# Altium schematic sheet sterio_mic MCU.SchDoc
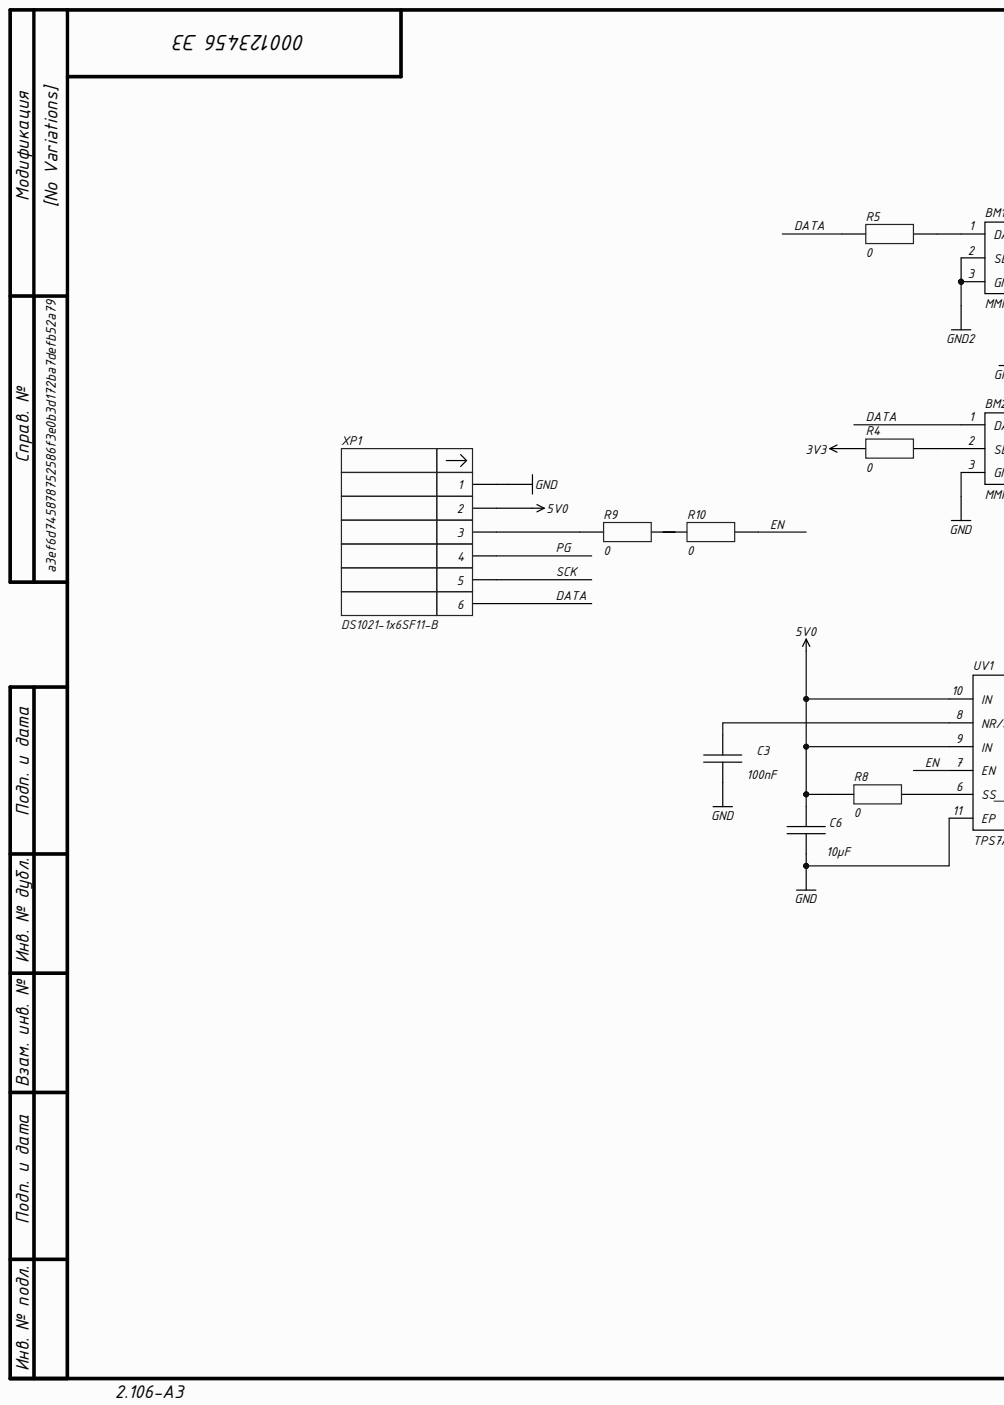
[diagram p0: sterio_mic MCU.SchDoc, left]
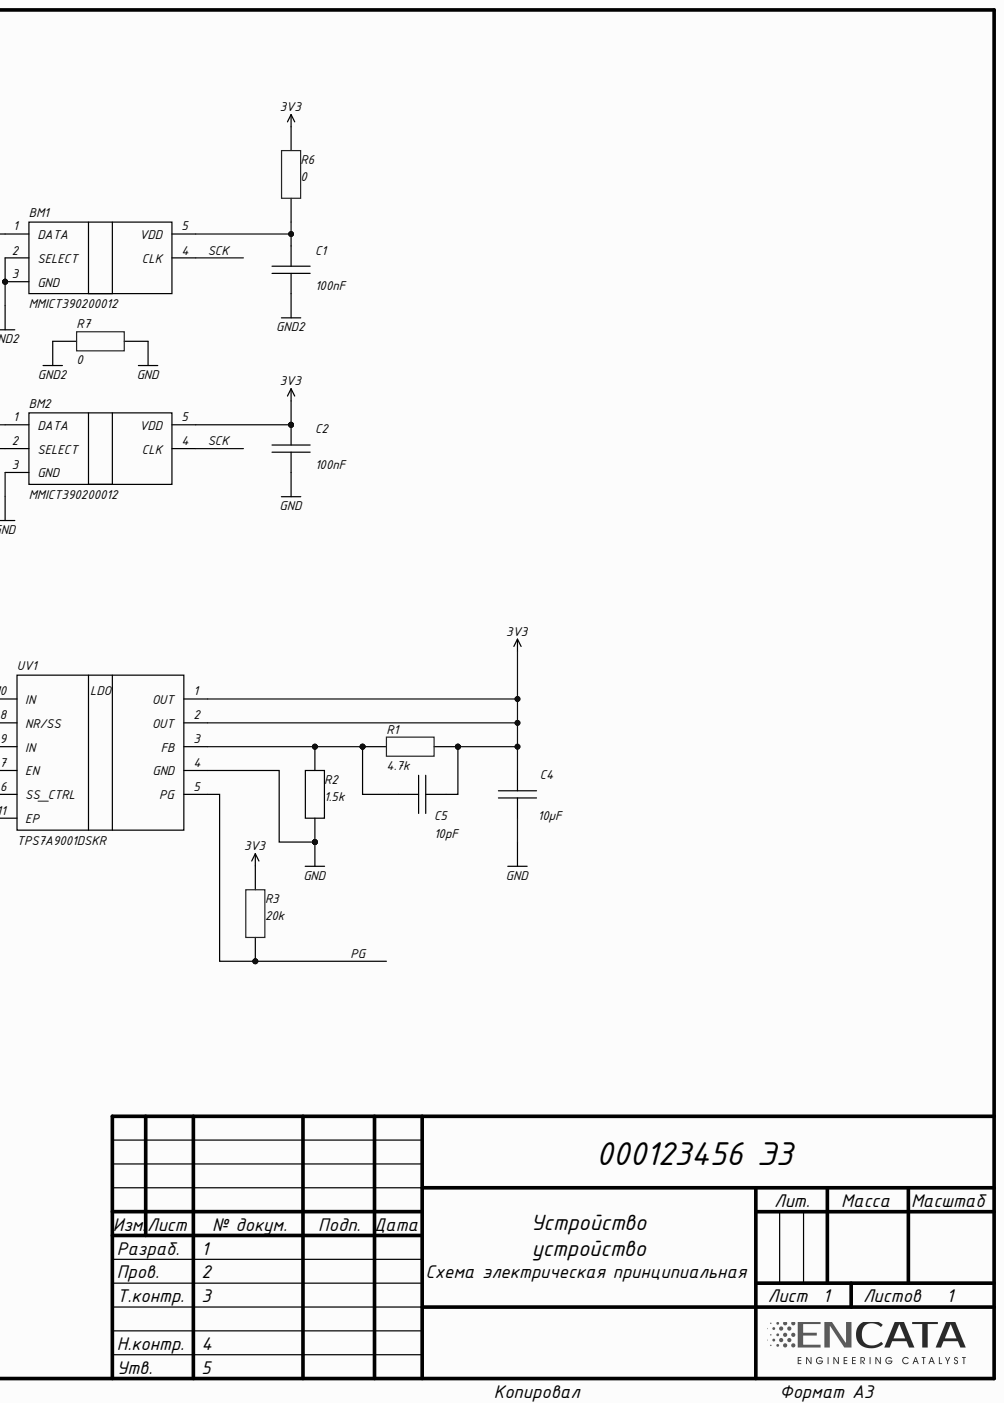
[diagram p1: sterio_mic MCU.SchDoc, right]

## Parts
BM1  MMICT390200012  [5-Terminal LGA_CAV]
BM2  MMICT390200012  [5-Terminal LGA_CAV]
C1  Capacitance: 100nF  [CAPC0805_H0.95_N]  (hidden comment: CC0805KPX7R9BB104)
C2  Capacitance: 100nF  [CAPC0805_H0.95_N]  (hidden comment: CC0805KPX7R9BB104)
C3  Capacitance: 100nF  [CAPC0805_H0.95_N]  (hidden comment: CC0805KPX7R9BB104)
C4  Capacitance: 10µF  [CAPC0805_H1.45_N]  (hidden comment: CL21B106KOQNNNE)
C5  Capacitance: 10pF  [CAPC0805_H0.95_N]  (hidden comment: CC0805FRNPO9BN100)
C6  Capacitance: 10µF  [CAPC0805_H1.45_N]  (hidden comment: CL21B106KOQNNNE)
R1  Resistance: 4.7k  [RESC0805_N]  (hidden comment: RC0805FR-074K7L)
R2  Resistance: 1.5k  [RESC0805_N]  (hidden comment: ERA6AEB152V)
R3  Resistance: 20k  [RESC0805_N]  (hidden comment: RC0805FR-0720KL)
R4  RC0805FR-070RL  [RESC0805_N]  (value not drawn)
R5  RC0805FR-070RL  [RESC0805_N]  (value not drawn)
R6  RC0805FR-070RL  [RESC0805_N]  (value not drawn)
R7  RC0805FR-070RL  [RESC0805_N]  (value not drawn)
R8  RC0805FR-070RL  [RESC0805_N]  (value not drawn)
R9  RC0805FR-070RL  [RESC0805_N]  (value not drawn)
R10  RC0805FR-070RL  [RESC0805_N]  (value not drawn)
UV1  TPS7A9001DSKR  [WSON-10]
XP1  DS1021-1x6SF11-B  [PLS-6(DS-1021-1x6)]

## Nets
3V3: BM2.5(VDD) C2.1 C4.1 C5.1 R1.1 R3.1 R4.1 R6.2 UV1.1(OUT) UV1.2(OUT)
5V0: C6.1 R8.1 UV1.9(IN) UV1.10(IN) XP1.2
GND: BM2.3(GND) C2.2 C3.2 C4.2 C6.2 R2.1 R7.1 UV1.4(GND) UV1.11(EP) XP1.1
GND2: BM1.2(SELECT) BM1.3(GND) C1.2 R7.2
DATA: BM2.1(DATA) R5.1 XP1.6
EN: R10.1 UV1.7(EN)
PG: R3.2 UV1.5(PG) XP1.4
SCK: BM1.4(CLK) BM2.4(CLK) XP1.5
NetBM1_1: BM1.1(DATA) R5.2
NetBM1_5: BM1.5(VDD) C1.1 R6.1
NetBM2_2: BM2.2(SELECT) R4.2
NetC3_1: C3.1 UV1.8(NR/SS)
NetC5_2: C5.2 R1.2 R2.2 UV1.3(FB)
NetR8_2: R8.2 UV1.6(SS_CTRL)
NetR9_1: R9.1 XP1.3
NetR9_2: R9.2 R10.2

## Library data of the parts
BM1 BM2 = CMP-SENS-0013-8: digital MEMS microphone; Manufacturer: TDK; Interface Type: PDM; Case/Package: LGA; Component Kind: Standard; HelpURL: https://static.chipdip.ru/lib/672/DOC011672161.pdf; Manufacturer Part Name: MMICT390200012; Author: t.dorakh; Library Name: EnCata Concord Pro
C1-C3 = CMP-CAP-CER-SMD-0001-5: 0805 100nF 50V ±10% X7R; Manufacturer: Yageo; Case Code (Imperial): 0805; Case Code (Metric): 2012; Case/Package: 0805; Component Kind: Standard; Depth: 850µm; Dielectric: X7R; Height: 850µm; HelpURL: https://www.yageo.com/upload/media/product/products/datasheet/mlcc/UPY-GPHC_X7R_6.3V-to-50V_20.pdf; Lead Free: Yes; Length: 2mm; Manufacturer Part Number: CC0805KPX7R9BB104; Series: СС; Tolerance: 10%; Voltage Rating: 50V; Width: 1.25mm; Author: m.seliadtsou; Library Name: EnCata Concord Pro
C4 C6 = CMP-CAP-CER-SMD-0082-5: 0805 10uF 16V ±10% X7R; Manufacturer: Samsung Electro-Mechanics; Case Code (Imperial): 0805; Case Code (Metric): 2012; Case/Package: 0805; Component Kind: Standard; Depth: 1.25mm; Dielectric: X7R; Height: 1.25mm; HelpURL: https://weblib.samsungsem.com/mlcc/mlcc-ec-data-sheet.do?partNumber=CL21B106KOQNFN; Lead Free: Yes; Length: 2mm; Manufacturer Part Number: CL21B106KOQNNNE; Max Operating Temperature: 125°C; Min Operating Temperature: -55°C; Series: CL; Tolerance: 10%; Voltage Rating: 16V; Width: 1.25mm; Author: m.boltromeyuk; Library Name: EnCata Concord Pro
C5 = CMP-CAP-CER-SMD-1997-4: 0805 10pF 50V ±1% NP0; Manufacturer: Yageo; Dielectric: C0G; Case Code (Imperial): 0805; Case Code (Metric): 2012; Case/Package: 0805; Component Kind: Standard; Depth: 600µm; Height: 600µm; HelpURL: http://www.yageo.ru/pdf/NP0_16V-to-100V.pdf; Lead Free: Yes; Length: 2mm; Manufacturer Part Number: CC0805FRNPO9BN100; Max Operating Temperature: 125°C; Min Operating Temperature: -55°C; Series: CC; Tolerance: 1%; Voltage Rating: 50V; Width: 1.25mm; Author: v.ivanchenko; Library Name: EnCata Concord Pro
R1 = CMP-RES-SMD-0600-6: RES SMD 4,7 KOHM 1% 1/8W 0805; Manufacturer: Yageo; Max Power Dissipation: 125mW; Temperature Coefficient: ±100ppm/C°; Voltage Rating: 150V; Case/Package: 0805; Component Kind: Standard; HelpURL: https://www.yageo.com/upload/media/product/productsearch/datasheet/rchip/PYu-RC_Group_51_RoHS_L_11.pdf; Manufacturer Part Number: RC0805FR-074K7L; Max Operating Temperature: 155°C; Min Operating Temperature: -55°C; Tolerance: 1%; Author: M.S. Koval; Library Name: EnCata Concord Pro
R2 = CMP-RES-SMD-0992-2: RES SMD 1.5 KOHM 0.1% 1/8W 0805  25ppm; Manufacturer: Panasonic Industrial Europe GmbH; Max Power Dissipation: 125mW; Temperature Coefficient: ±25ppm/C°; Voltage Rating: 100V; Case/Package: 0805; Component Kind: Standard; HelpURL: https://mediap.industry.panasonic.eu/assets/imported/industrial.panasonic.com/cdbs/www-data/pdf/RDM0000/AOA0000C307.pdf; Manufacturer Part Number: ERA6AEB152V; Max Operating Temperature: 155°C; Min Operating Temperature: -55°C; Tolerance: 0.1%; Author: u.sushko; Library Name: EnCata Concord Pro
R3 = CMP-RES-SMD-0615-6: RES SMD 20 KOHM 1% 1/8W 0805; Manufacturer: Yageo; Max Power Dissipation: 125mW; Temperature Coefficient: ±100ppm/C°; Voltage Rating: 150V; Case/Package: 0805; Component Kind: Standard; HelpURL: https://www.yageo.com/upload/media/product/productsearch/datasheet/rchip/PYu-RC_Group_51_RoHS_L_11.pdf; Manufacturer Part Number: RC0805FR-0720KL; Max Operating Temperature: 155°C; Min Operating Temperature: -55°C; Tolerance: 1%; Author: M.S. Koval; Library Name: EnCata Concord Pro
R4-R10 = CMP-RES-SMD-0511-6: RES SMD 0 OHM JUMPER 1% 1/8W 0805; Manufacturer: Yageo; Max Power Dissipation: 125mW; Resistance: 0; Voltage Rating: 150V; Case/Package: 0805; Component Kind: Standard; HelpURL: https://www.yageo.com/upload/media/product/productsearch/datasheet/rchip/PYu-RC_Group_51_RoHS_L_11.pdf; Manufacturer Part Number: RC0805FR-070RL; Max Operating Temperature: 155°C; Min Operating Temperature: -55°C; Tolerance: 1%; Author: M.S. Koval; Library Name: EnCata Concord Pro
UV1 = CMP-IC-POW_MGMT-LDO0037-4: Adjustable Positive LDO Regulator, 0.8V Min, 5.7V Max, 0.2V Dropout, PDSO10; Manufacturer: Texas Instruments; Max Input Voltage: 6.5V; Max Output Current: 500mA; Min Input Voltage: 1.4V; Min Output Voltage: 800mV; Component Kind: Standard; HelpUrl: https://www.ti.com/lit/ds/symlink/tps7a90.pdf?ts=1743754398637&ref_url=https%253A%252F%252Fwww.ti.com%252Fproduct%252FTPS7A90; Manufacturer Part Number: TPS7A9001DSKR; Max Operating Temperature: 125°C; Max Output Voltage: 5.7V; Min Operating Temperature: -40°C; Mount: Surface; Output Type: Adjustable; Author: t.dorakh; Library Name: EnCata Concord Pro
XP1 = CMP-CON-B2B-0048-3: 2.54mm, 6 pin, Male, 3A, Vertical mounting, CONNFLY; Contact Resistance: 20m; Gender: Non-Gendered; Number of Contacts: 6; Voltage Rating: 250V; Color: Black; Component Kind: Standard; Contact Current Rating: 3A; Contact Gender: Male; Contact Plating: Gold; HelpURL: http://outer.connfly.group/static/upload/file/pdf/DS1021.pdf; Manufacturer: CONNFLY; Manufacturer Part Number: DS1021-1x6SF11-B; Max Operating Temperature: 105°C; Min Operating Temperature: -40°C; Mount: Through Hole; Orientation: Vertical; Pitch: 2.54mm; Author: a.antipov; Library Name: EnCata Concord Pro
